# Altium schematic sheet FunCon.SchDoc
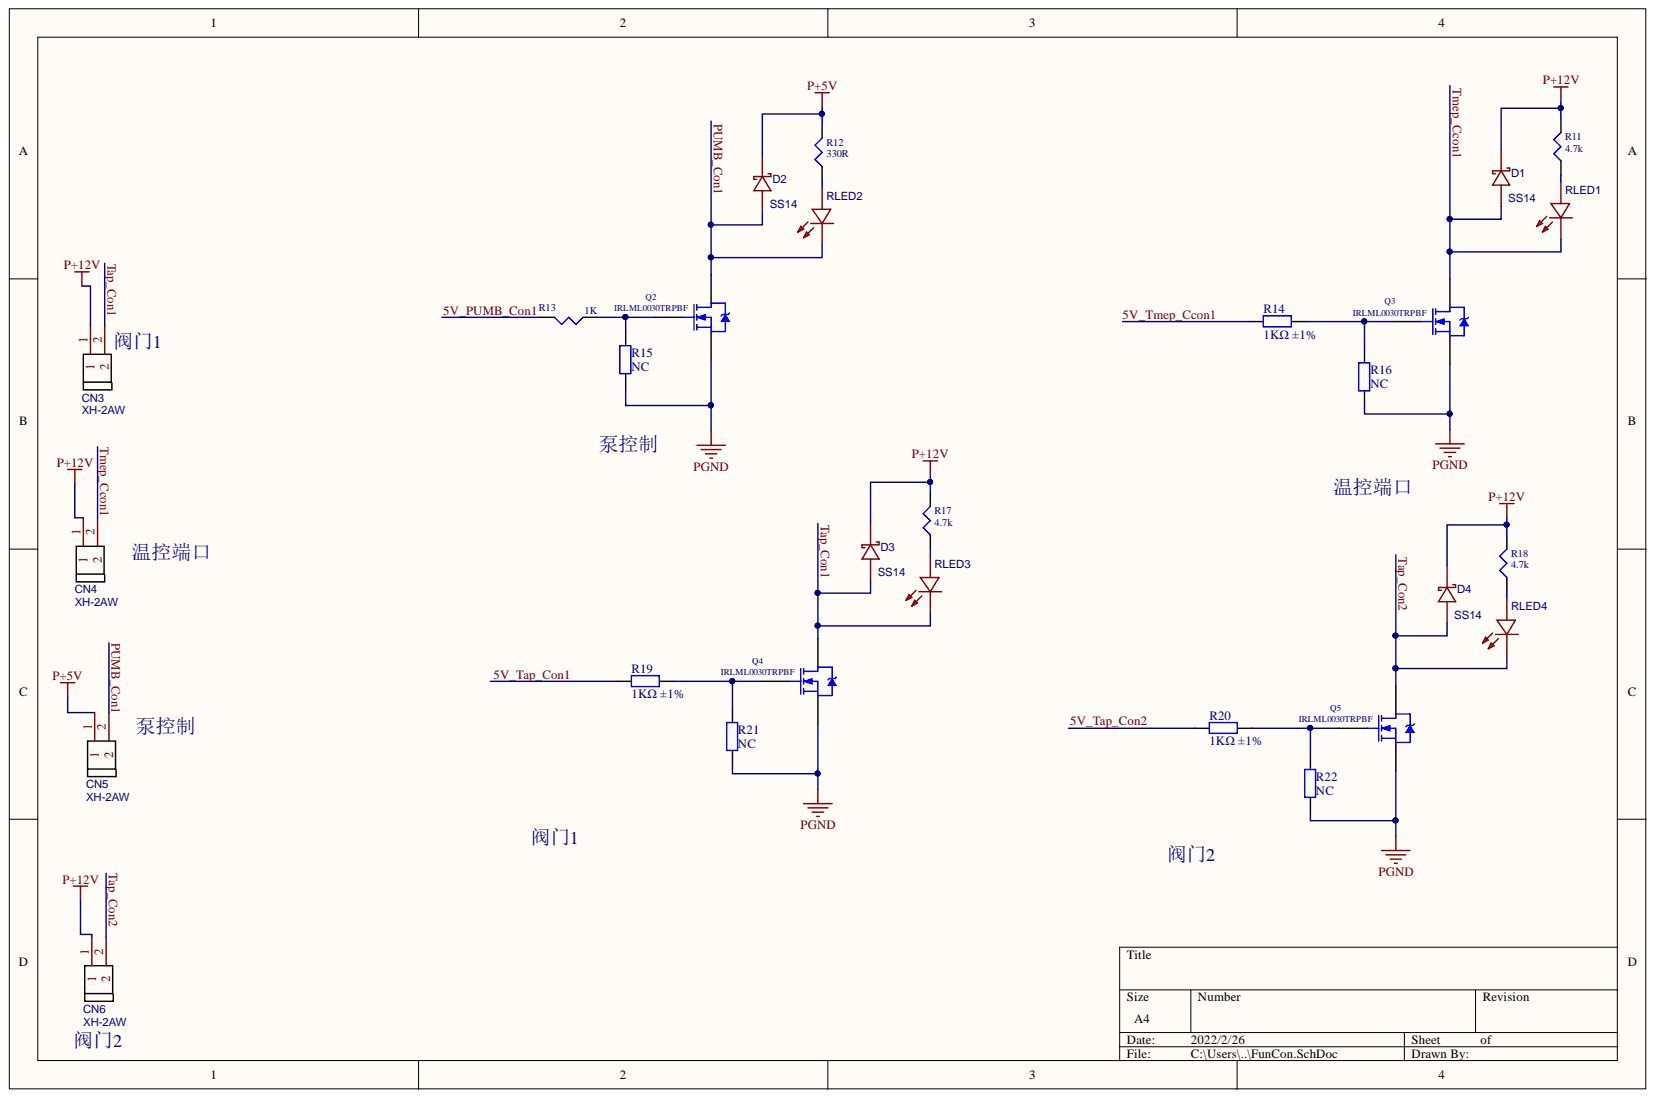
[diagram p0: FunCon.SchDoc]

## Parts
CN3  XH-2AW  [XH-2P]
CN4  XH-2AW  [XH-2P]
CN5  XH-2AW  [XH-2P]
CN6  XH-2AW  [XH-2P]
D1  SS14  [SS24-SMA]
D2  SS14  [SS24-SMA]
D3  SS14  [SS24-SMA]
D4  SS14  [SS24-SMA]
Q2  IRLML0030TRPBF  [SOT-23]
Q3  IRLML0030TRPBF  [SOT-23]
Q4  IRLML0030TRPBF  [SOT-23]
Q5  IRLML0030TRPBF  [SOT-23]
R11  4.7k  [R0603]
R12  330R  [R0603]
R13  1K  [R0603]
R14  1KΩ ±1%  [R0603]
R15  NC  [R0603]
R16  NC  [R0603]
R17  4.7k  [R0603]
R18  4.7k  [R0603]
R19  1KΩ ±1%  [R0603]
R20  1KΩ ±1%  [R0603]
R21  NC  [R0603]
R22  NC  [R0603]
RLED1  LTST-C191TGKT  [LED0603]  (value not drawn)
RLED2  LTST-C191TGKT  [LED0603]  (value not drawn)
RLED3  LTST-C191TGKT  [LED0603]  (value not drawn)
RLED4  LTST-C191TGKT  [LED0603]  (value not drawn)

## Nets
P+5V: CN5.1 D2.1 R12.2
P+12V: CN3.1 CN4.1 CN6.1 D1.1 D3.1 D4.1 R11.2 R17.2 R18.2
PGND: Q2.2 Q3.2 Q4.2 Q5.2 R15.2 R16.2 R21.2 R22.2
5V_PUMB_Con1: R13.2
5V_Tap_Con1: R19.1
5V_Tap_Con2: R20.1
5V_Tmep_Ccon1: R14.1
PUMB_Con1: CN5.2 D2.2 Q2.3 RLED2.1
Tap_Con1: CN3.2 D3.2 Q4.3 RLED3.1
Tap_Con2: CN6.2 D4.2 Q5.3 RLED4.1
Tmep_Ccon1: CN4.2 D1.2 Q3.3 RLED1.1
NetQ2_1: Q2.1 R13.1 R15.1
NetQ3_1: Q3.1 R14.2 R16.1
NetQ4_1: Q4.1 R19.2 R21.1
NetQ5_1: Q5.1 R20.2 R22.1
NetR11_1: R11.1 RLED1.2
NetR12_1: R12.1 RLED2.2
NetR17_1: R17.1 RLED3.2
NetR18_1: R18.1 RLED4.2

## Library data of the parts
CN3-CN6 = XH-2AW: BOM_Manufacturer: BOOMELE; BOM_JLCPCB Part Class: Extended Part; BOM_Manufacturer Part: XH-2AW; BOM_Supplier: LCSC; BOM_Supplier Part: C33132; Component Kind: Standard; spicePre: C; spiceSymbolName: XH-2AW
D1-D4 = SS24AD: BOM_Manufacturer: SEMTECH; SMT Type: Extend; BOM_JLCPCB SMD: Yes; BOM_Manufacturer Part: SS24AD; BOM_Supplier: LCSC; BOM_Supplier Part: C84503; Component Kind: Standard; image: //image.lceda.cn/szlcsc/C84503.jpg; spicePre: D; spiceSymbolName: SS24AD
Q2-Q5 = IRF3205: HEXFET Power MOSFET; Note: Pin 2 is electrically connected to pin 4.; Component Kind: Standard; ComponentLink1Description: Manufacturer Link; ComponentLink1URL: http://www.irf.com/; ComponentLink2Description: Datasheet; ComponentLink2URL: http://www.irf.com/product-info/datasheets/data/irf3205.pdf; DatasheetVersion: Aug-1997; LatestRevisionDate: 17-Jul-2002; LatestRevisionNote: Re-released for DXP Platform.; Library Name: IR Discrete MOSFET - Power.IntLib; PackageDescription: TO, Thru-Hole, Vertical, Heatsink Mounted; 3 In-Line Leads; Pitch 2.54 mm; PackageReference: TO-220AB; PackageVersion: Feb-1997; Published: 8-Jun-2000; Publisher: Altium Limited
R11-R13 R17 R18 = RES 0805: Component Kind: Standard; Library Name: C-LifeReal_1.SchLib
R14-R16 R19-R22 = 0603 4.7Ω (4R70) 1%: 贴片电阻; Component Kind: Standard; ComponentLink1Description: 供应商链接; ComponentLink1URL: http://www.szlcsc.com/product/details_23891.html; Library Name: RES.SchLib; Package: 0603; Supplier: LC; SuppliersPartNumber: C23164
RLED1-RLED4 = LTST-C191TGKT: BOM_Manufacturer: LITEON; Contributor: LCSC; BOM_Manufacturer Part: LTST-C191TGKT; BOM_Supplier: LCSC; BOM_Supplier Part: C125101; Component Kind: Standard; spicePre: L; spiceSymbolName: LTST-C191TGKT
